# Altium schematic sheet USB.SchDoc
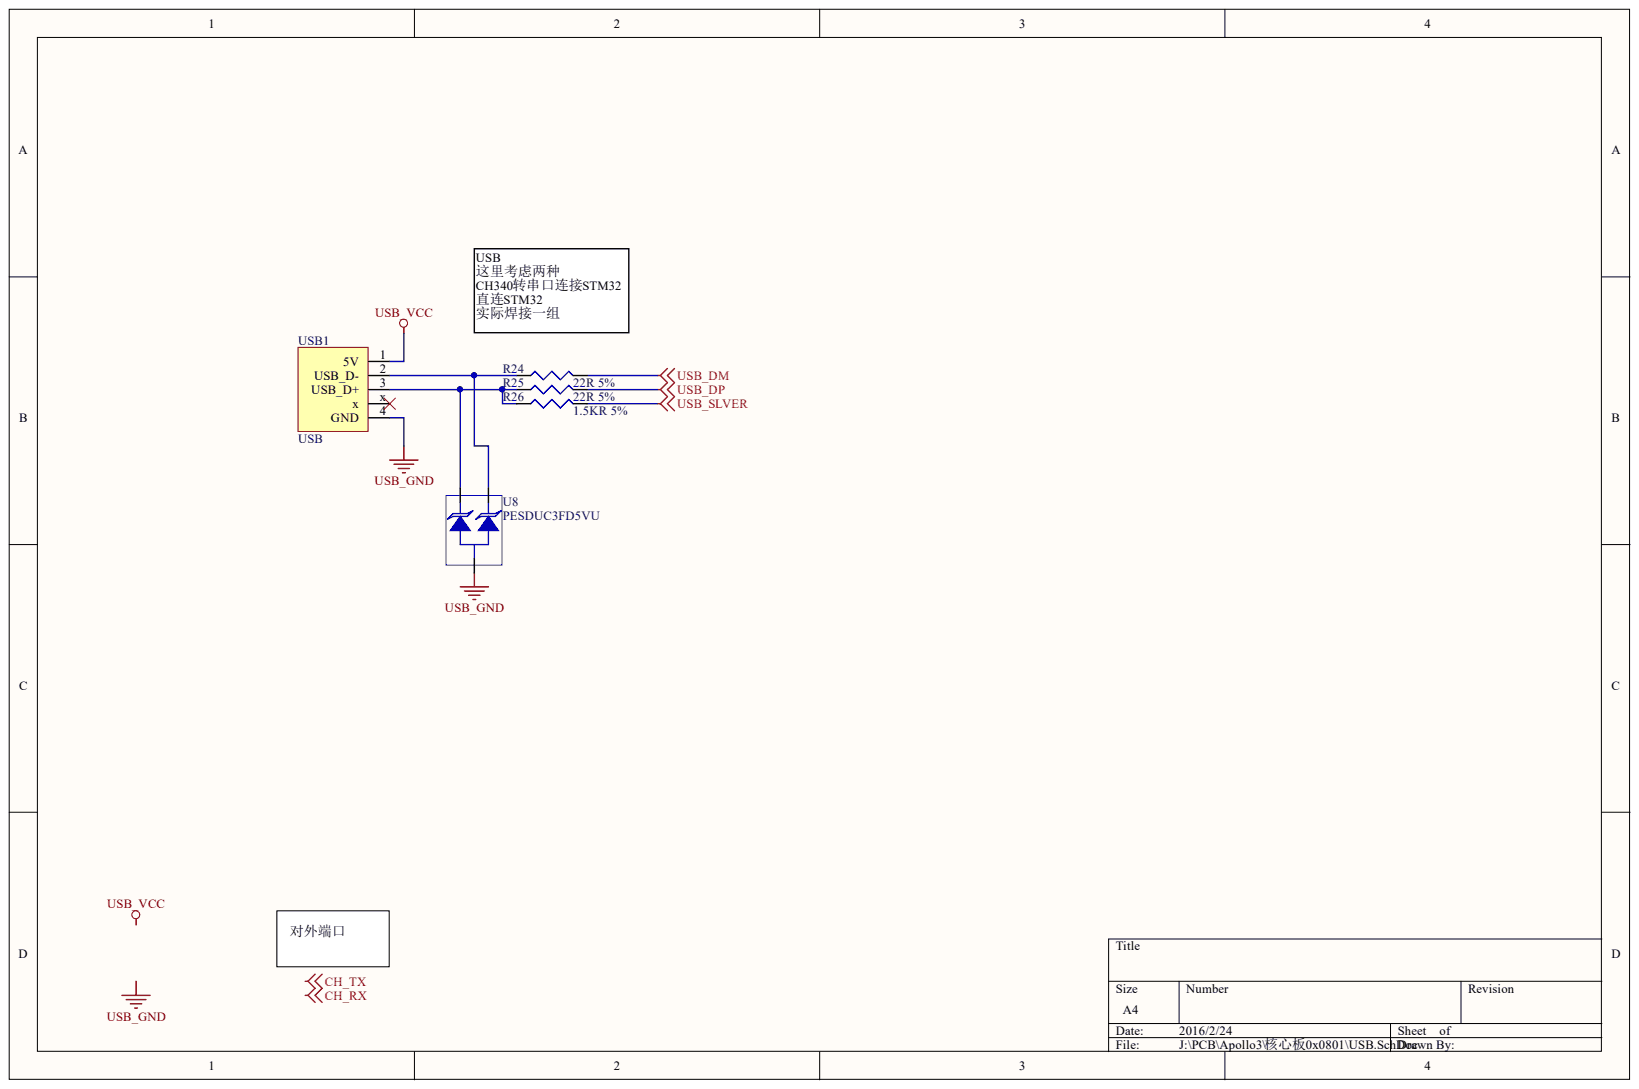
[diagram p0: USB.SchDoc]

## Parts
R24  22R 5%  [RESC1005X04N]
R25  22R 5%  [RESC1005X04N]
R26  1.5KR 5%  [RESC1005X04N]
U8  PESDUC3FD5VU  [DFN65P100X39-3N]
USB1  USB  [USB_Micro_3]

## Nets
USB_DM: R24.2
USB_DP: R25.2
USB_GND: U8.3 USB1.4(GND)
USB_SLVER: R26.2
USB_VCC: USB1.1(5V)
NetR24_1: R24.1 U8.2 USB1.2(USB_D-)
NetR25_1: R25.1 R26.1 U8.1 USB1.3(USB_D+)
CH_RX:
CH_TX:

Not connected: USB1 x

## Library data of the parts
R24-R26 = R: General Resistors; Component Kind: Standard; Library Name: RCL_Standard.SchLib
U8 = PESDUC3FD5VU: 2路 ESD防护; Component Kind: Standard; Library Name: Safeguards.SchLib
USB1 = USB: Component Kind: Standard; Library Name: Connector.SchLib
